# Altium schematic sheet Sensores.SchDoc(Sensores)
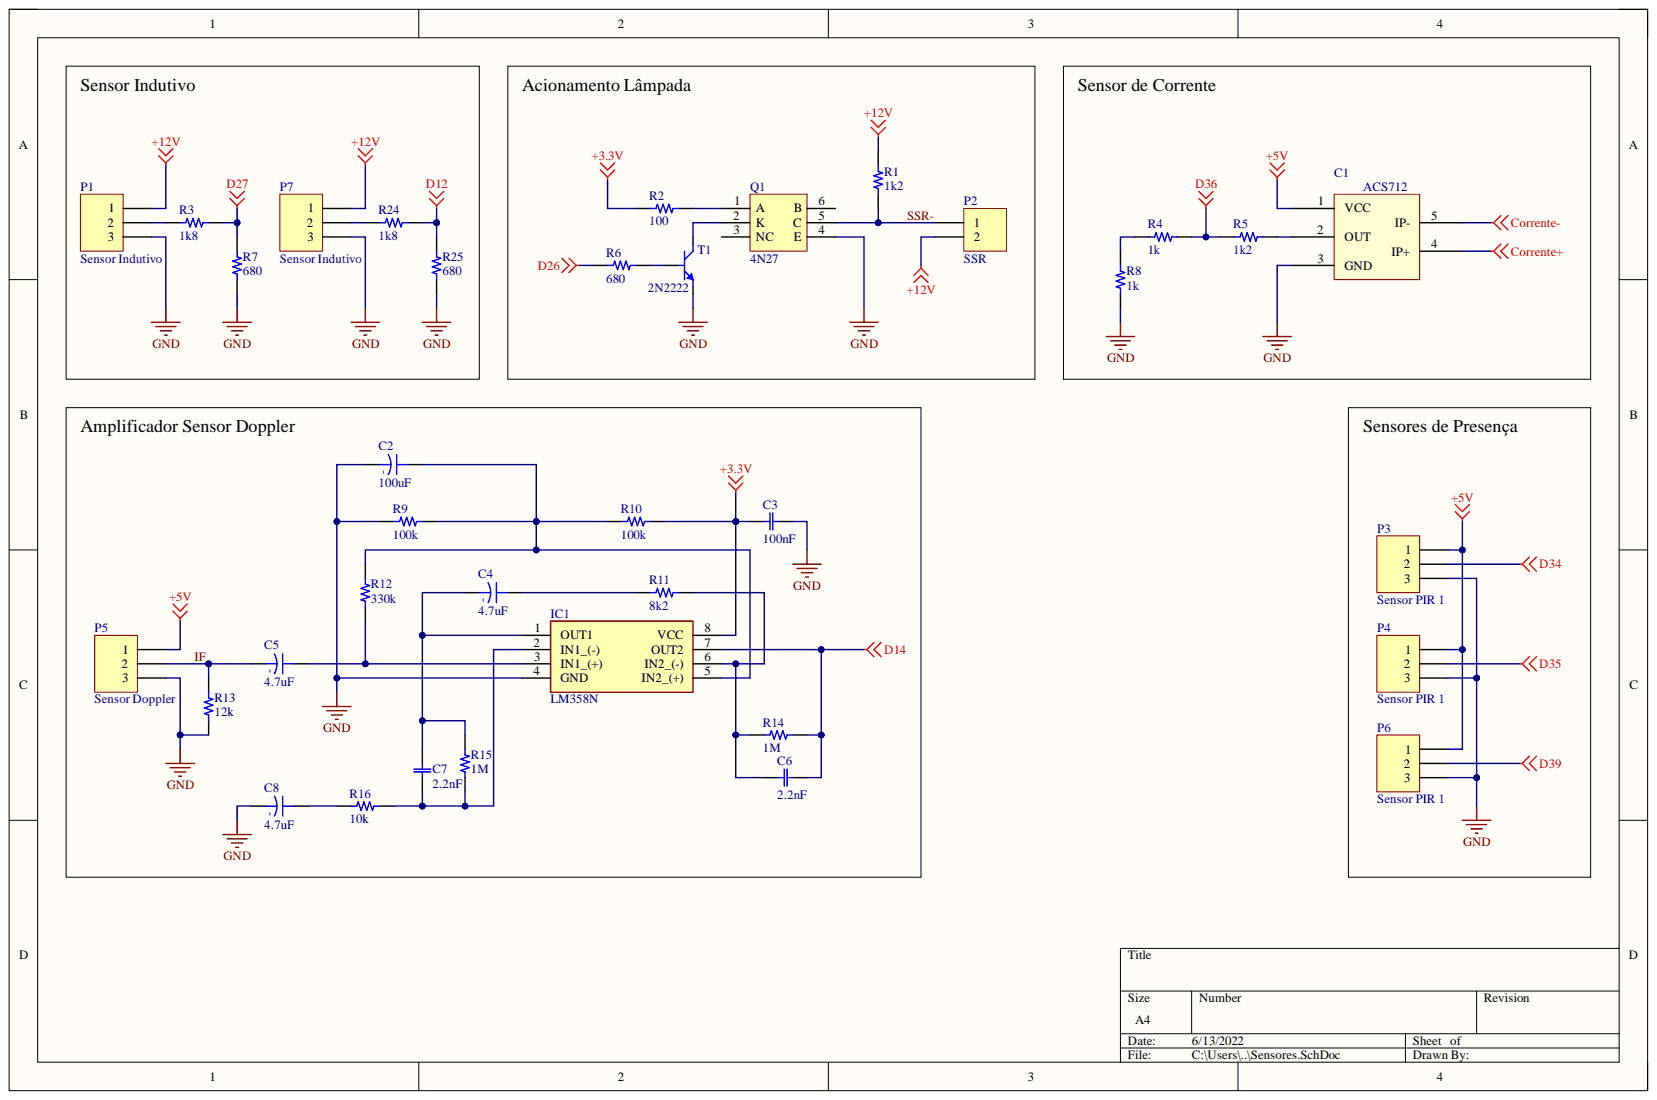
[diagram p0: Sensores.SchDoc]

## Parts
C1  Current Sensor Module ACS712  [Current Sensor Module ACS712-Footprint-1]  (value not drawn)
C2  100uF  [CAP DIA 10mm-Footprint-1]
C3  100nF  [CAP CERAMIC DIA 5mm-Footprint-1]
C4  4.7uF  [CAP DIA 5mm-Footprint-1]
C5  4.7uF  [CAP DIA 5mm-Footprint-1]
C6  2.2nF  [CAP CERAMIC DIA 5mm-Footprint-1]
C7  2.2nF  [CAP CERAMIC DIA 5mm-Footprint-1]
C8  4.7uF  [CAP DIA 5mm-Footprint-1]
IC1  LM358N  [DIP794W56P254L959H533Q8N]
P1  Sensor Indutivo  [HDR1X3]
P2  SSR  [HDR1X2]
P3  Sensor PIR 1  [HDR1X3]  (value not drawn)
P4  Sensor PIR 1  [HDR1X3]  (value not drawn)
P5  Sensor Doppler  [HDR1X3]
P6  Sensor PIR 1  [HDR1X3]  (value not drawn)
P7  Sensor Indutivo  [HDR1X3]
Q1  4N27  [4N27-Footprint-1]
R1  1k2  [RESISTOR 1_4W-Footprint-1]
R2  100  [RESISTOR 1_4W-Footprint-1]
R3  1k8  [RESISTOR 1_4W-Footprint-1]
R4  1k  [RESISTOR 1_4W-Footprint-1]
R5  1k2  [RESISTOR 1_4W-Footprint-1]
R6  680  [RESISTOR 1_4W-Footprint-1]
R7  680  [RESISTOR 1_4W-Footprint-1]
R8  1k  [RESISTOR 1_4W-Footprint-1]
R9  100k  [RESISTOR 1_4W-Footprint-1]
R10  100k  [RESISTOR 1_4W-Footprint-1]
R11  8k2  [RESISTOR 1_4W-Footprint-1]
R12  330k  [RESISTOR 1_4W-Footprint-1]
R13  12k  [RESISTOR 1_4W-Footprint-1]
R14  1M  [RESISTOR 1_4W-Footprint-1]
R15  1M  [RESISTOR 1_4W-Footprint-1]
R16  10k  [RESISTOR 1_4W-Footprint-1]
R24  1k8  [RESISTOR 1_4W-Footprint-1]
R25  680  [RESISTOR 1_4W-Footprint-1]
T1  2N2222  [2N2222-Footprint-1]

## Nets
+3.3V: C3.2 IC1.8(VCC) R2.2 R10.1
+5V: C1.1(VCC) P3.1 P4.1 P5.1 P6.1
+12V: P1.1 P2.2 P7.1 R1.2
Corrente+: C1.4(IP+)
Corrente-: C1.5(IP-)
D12: R24.2 R25.2
D14: C6.1 IC1.7(OUT2) R14.2
D26: R6.2
D27: R3.2 R7.2
D34: P3.2
D35: P4.2
D36: R4.1 R5.2
D39: P6.2
GND: C1.3(GND) C2.2 C3.1 C8.2 IC1.4(GND) P1.3 P3.3 P4.3 P5.3 P6.3 P7.3 Q1.4(E) R7.1 R8.1 R9.2 R13.1 R25.1 T1.3
IF: C5.2 P5.2 R13.2
SSR-: P2.1 Q1.5(C) R1.1
NetC1_2: C1.2(OUT) R5.1
NetC2_1: C2.1 IC1.5(IN2_(+)) R9.1 R10.2 R12.2
NetC4_1: C4.1 R11.2
NetC4_2: C4.2 C7.2 IC1.1(OUT1) R15.1
NetC5_1: C5.1 IC1.3(IN1_(+)) R12.1
NetC6_2: C6.2 IC1.6(IN2_(-)) R11.1 R14.1
NetC7_1: C7.1 IC1.2(IN1_(-)) R15.2 R16.1
NetC8_1: C8.1 R16.2
NetP1_2: P1.2 R3.1
NetP7_2: P7.2 R24.1
NetQ1_1: Q1.1(A) R2.1
NetQ1_2: Q1.2(K) T1.1
NetR4_2: R4.2 R8.2
NetR6_1: R6.1 T1.2

Not connected: Q1 3(NC) 6(B)

## Library data of the parts
C1 = CMP-00001-1: Current Sensor with ACS712 IC; Component Kind: Standard; Library Name: Eletrônica 2
C2 = CMP-00009-2: CAPACITOR DIAMENTER 10mm; Component Kind: Standard; Library Name: Eletrônica 2
C3 C6 C7 = CMP-00011-2: DIAMETER 5mm PITCH 2mm; Component Kind: Standard; Library Name: Eletrônica 2
C4 C5 C8 = CMP-00008-3: CAPACITOR DIAMETRO 5mm; Component Kind: Standard; Library Name: Eletrônica 2
IC1 = LM358N: Integrated Circuit; Manufacturer_Name: ON Semiconductor; Arrow Part Number: LM358N; Arrow Price/Stock: https://www.arrow.com/en/products/lm358n/on-semiconductor; Component Kind: Standard; Datasheet Link: http://www.onsemi.com/pub/Collateral/LM358A-D.pdf; Height: 5.33mm; Manufacturer_Part_Number: LM358N; Mouser Part Number: 512-LM358N; Mouser Price/Stock: https://www.mouser.co.uk/ProductDetail/ON-Semiconductor-Fairchild/LM358N?qs=K0aVCp%2F7QwafyMXZgr%2FPfw%3D%3D
P1 P3-P7 = Header 3: Header, 3-Pin; Component Kind: Standard; LatestRevisionDate: 17-Jul-2002; LatestRevisionNote: Re-released for DXP Platform.; Library Name: Miscellaneous Connectors.IntLib; Signal Integrity: Connector; Publisher: Altium Limited
P2 = Header 2: Header, 2-Pin; Component Kind: Standard; LatestRevisionDate: 17-Jul-2002; LatestRevisionNote: Re-released for DXP Platform.; Library Name: Miscellaneous Connectors.IntLib; Signal Integrity: Connector; Publisher: Altium Limited
Q1 = CMP-00026-00001-1: Transistor Output Optocouplers DIP-6 PHOTO TRANS; Component Kind: Standard; Library Name: Eletrônica 2
R1-R16 R24 R25 = CMP-00007-2: Component Kind: Standard; Library Name: Eletrônica 2
T1 = CMP-00010-1: Transistor 2N2222; Component Kind: Standard; Library Name: Eletrônica 2
